# Altium schematic sheet MCU.SchDoc(MCU)
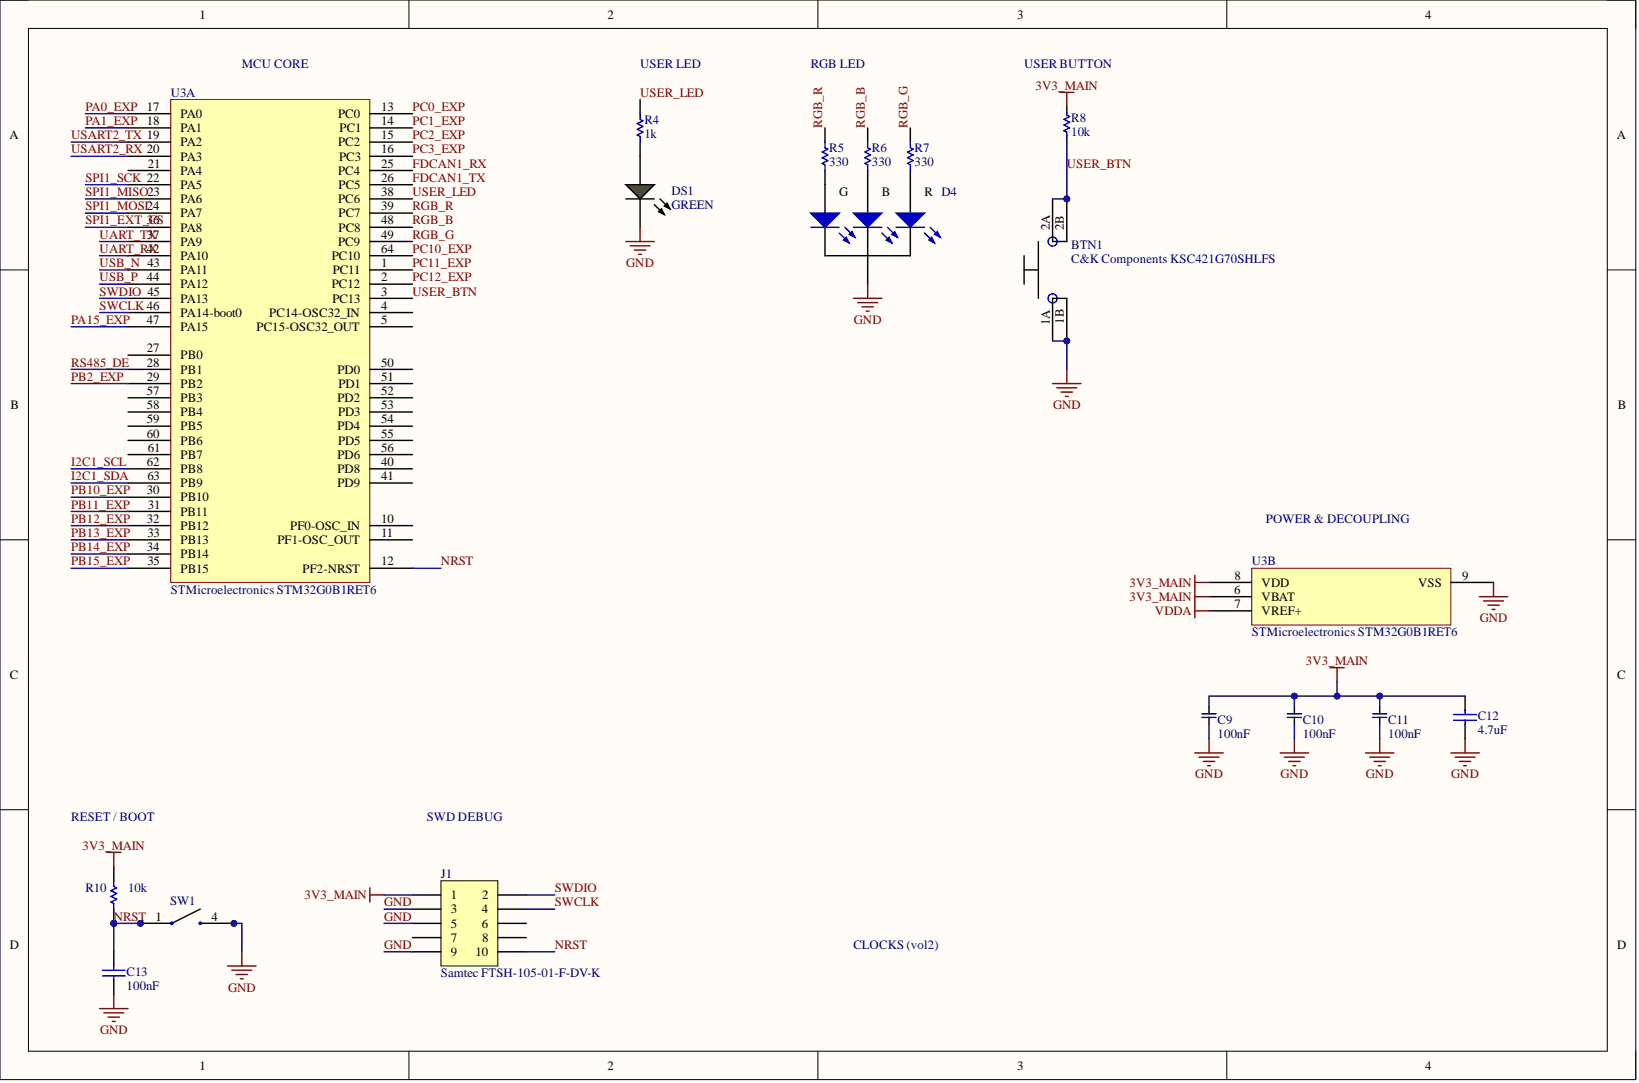
[diagram p0: MCU.SchDoc]

## Parts
BTN1  C&K Components KSC421G70SHLFS  [PCB-uozrol6uzfra7nf3d66d-1]
C9  100nF
C10  100nF
C11  100nF
C12  4.7uF
C13  100nF
D4  Kingbright APFA3010SEKJ3ZGKQBKC  [PCB-xa6wagpttn61v02uvygv-1]  (value not drawn)
DS1  GREEN  [PCB-0runrzwmvqwsv44lu00p-2]
J1  Samtec FTSH-105-01-F-DV-K  Manufacturer Part Number: FTSH-105-01-F-DV-K
R4  1k  [PCB-m2w64qs661eeqm4r43mx-1]
R5  330  [PCB-0002-00066-3]
R6  330  [PCB-0002-00066-3]
R7  330  [PCB-0002-00066-3]
R8  10k
R10  10k
SW1  TE Connectivity FSM2JSMA  [PCB-ceqr8z8zluiv0xnbvd9i-1]  (value not drawn)
U3  STMicroelectronics STM32G0B1RET6  Manufacturer Part Number: STM32G0B1RET6  (parts A,B)

## Nets
3V3_MAIN: C9.2 C10.2 C11.2 C12.2 J1.1 R8.2 R10.2 U3.6(VBAT) U3.8(VDD)
VDDA: U3.7(VREF+)
FDCAN1_RX: U3.25(PC4)
FDCAN1_TX: U3.26(PC5)
GND: BTN1.1A BTN1.1B C9.1 C10.1 C11.1 C12.1 C13.1 D4.2 DS1.2 J1.3 J1.5 J1.9 SW1.4 U3.9(VSS)
I2C1_SCL: U3.62(PB8)
I2C1_SDA: U3.63(PB9)
NRST: C13.2 J1.10 R10.1 SW1.1 U3.12(PF2-NRST)
PA0_EXP: U3.17(PA0)
PA1_EXP: U3.18(PA1)
PA15_EXP: U3.47(PA15)
PB2_EXP: U3.29(PB2)
PB10_EXP: U3.30(PB10)
PB11_EXP: U3.31(PB11)
PB12_EXP: U3.32(PB12)
PB13_EXP: U3.33(PB13)
PB14_EXP: U3.34(PB14)
PB15_EXP: U3.35(PB15)
PC0_EXP: U3.13(PC0)
PC1_EXP: U3.14(PC1)
PC2_EXP: U3.15(PC2)
PC3_EXP: U3.16(PC3)
PC10_EXP: U3.64(PC10)
PC11_EXP: U3.1(PC11)
PC12_EXP: U3.2(PC12)
RGB_B: R6.2 U3.48(PC8)
RGB_G: R7.2 U3.49(PC9)
RGB_R: R5.2 U3.39(PC7)
RS485_DE: U3.28(PB1)
SPI1_EXT_CS: U3.36(PA8)
SPI1_MISO: U3.23(PA6)
SPI1_MOSI: U3.24(PA7)
SPI1_SCK: U3.22(PA5)
SWCLK: J1.4 U3.46(PA14-boot0)
SWDIO: J1.2 U3.45(PA13)
UART_RX: U3.42(PA10)
UART_TX: U3.37(PA9)
USART2_RX: U3.20(PA3)
USART2_TX: U3.19(PA2)
USB_N: U3.43(PA11)
USB_P: U3.44(PA12)
USER_BTN: BTN1.2A BTN1.2B R8.1 U3.3(PC13)
USER_LED: R4.2 U3.38(PC6)
NetD4_1: D4.1 R7.1
NetD4_3: D4.3 R5.1
NetD4_4: D4.4 R6.1
NetDS1_1: DS1.1 R4.1

Not connected: J1 6 7 8; U3 4(PC14-OSC32_IN) 5(PC15-OSC32_OUT) 10(PF0-OSC_IN) 11(PF1-OSC_OUT) 21(PA4) 27(PB0) 40(PD8) 41(PD9) 50(PD0) 51(PD1) 52(PD2) 53(PD3) 54(PD4) 55(PD5) 56(PD6) 57(PB3) 58(PB4) 59(PB5) 60(PB6) 61(PB7)

## Library data of the parts
BTN1 = CMP-7597bcf2a0aaaa50-3: Switch Tactile N.O. SPST Round Button Gull Wing 0.05A 32VDC 1VA 300000Cycle 2.12N SMD T/R; Contact Resistance: 100 mΩ (max); Contacts Type: SPST-NO; Lead-Free Status: Lead Free; Actuator Type: Button; Component Kind: Standard; Contact Material: Silver; Contact Plating: Silver; Library Name: My Personal Space; Manufacturer Part Number: KSC421G70SHLFS; Mounting Style: Surface Mount; Octopart URL: https://octopart.com/ksc421g70shlfs-c%26k+components-7336027; OctopartID: 7597bcf2a0aaaa50; Operating Temperature: 85.0 °C (max); Orientation: Vertical; Packaging: Tape & Reel (TR); REACH SVHC Compliance: No SVHC; RoHS: Compliant; Size-Length: 6.20 mm; Size-Width: 6.20 mm
C9-C11 = CMP-1af8d38ac64bc53f-24: Ceramic capacitor SMD-0603 100nF 50V X7R# K +-10%, Samsung, RoHS; Manufacturer Part Number: CL10B104KB8NNNC; Capacitance Tolerance: ±10%; Dielectric Characteristic: X7R; Lead-Free Status: Lead Free; Voltage Rating (DC): 50.0 V; Capacitance: 100 nF; Case/Package: 0603; Component Kind: Standard; DATE: 2024_09_30; JLCPCB Part #: C1591; Library Name: My Personal Space; Mounting Style: Surface Mount; Octopart URL: https://octopart.com/part/samsung-electro-mechanics/CL10B104KB8NNNC; OctopartID: 1af8d38ac64bc53f; PN Digikey: 1276-1000-1-ND; PN FAB: CL10B104KB8NNNC; PN JLCPCB: C1591; Price DK: 0.1; Price JLCPCB: 0.0023; RoHS: Compliant
C12 = CMP-8267229-2: Multilayer Ceramic Capacitors MLCC - SMD/SMT 0805 4.7uF 35volts X5R 20%; Manufacturer Part Number: GRM219R6YA475MA73D; Capacitance: 4.7e-06 F; Case/Package (SI): 2012; Voltage Rating (DC): 35.0 V; Capacitance Tolerance: ±20%; Case/Package: 0805; Component Kind: Standard; Dielectric Characteristic: X5R; Library Name: My Personal Space; Lifecycle Status: Active; Octopart Url: https://octopart.com/grm219r6ya475ma73d-murata-27475762; OctopartID: 05c0cd691f94da07; Packaging: Cut Tape (CT); REACH SVHC Compliance: No SVHC; RoHS: Compliant; Size-Length: 0.002 m; Size-Thickness: 0.00085 m; Size-Width: 0.00125 m
C13 = CMP-e7d62c864ecd3811-3: Capacitor; ECWF, Met Polypropylene Film Cap, Radial, 50V, 10uF, 5%, Blk ST; Manufacturer Part Number: ECW-F4474JL; Capacitance: 470 nF; Lead Length: 25.0 mm; Lead-Free Status: Lead Free; Capacitance Tolerance: ±5%; Component Kind: Standard; Dielectric Material: Polypropylene; Library Name: My Personal Space; Lifecycle Status: Not Listed by Manufacturer; Mounting Style: Through Hole; Octopart Url: https://octopart.com/ecw-f4474jl-panasonic-39488637; OctopartID: e7d62c864ecd3811; Operating Temperature: 105 °C (max); Packaging: Bulk; RoHS: Compliant; Size-Height: 5mm; Size-Length: 11mm; Voltage Rating (DC): 50v
D4 = CMP-91425c46545c4e85-2: 3.0MMX1.0MM Right Angle SMD Chip Led Lamp; Detailed Description: Red, Green, Blue (RGB) 625nm Red, 525nm Green, 465nm Blue LED Indication - Discrete 2.2V Red, 3.3V Green, 3.3V Blue 4-SMD, No Lead; Component Kind: Standard; Digikey Part Number: 754-2148-1-ND; Library Name: My Personal Space; Manufacturer: Kingbright; Manufacturer Part Number: APFA3010SEKJ3ZGKQBKC; Octopart URL: https://octopart.com/apfa3010sekj3zgkqbkc-kingbright-68083832; OctopartID: 91425c46545c4e85; RoHS: Compliant
DS1 = CMP-e1642866a00b3ea3-15: 2.4 V Green LED 1608 (0603) SMD, Lite-On LTST-C190KGKT; Case/Package (SI): 1608; Forward Voltage: 2.00 V; Lead-Free Status: Lead Free; Lens Type: Non-Diffused; Luminous Intensity: 35.0 mcd; Viewing Angle: 130 °; Wavelength: 574 nm; Case/Package: 0603; Color: Green; Component Kind: Standard; Library Name: My Personal Space; Manufacturer Part Number: LTST-C190KGKT; Mounting Style: Surface Mount, Vertical; Octopart URL: https://octopart.com/ltst-c190kgkt-lite-on-549897; OctopartID: e1642866a00b3ea3; Operating Temperature: 85.0 °C (max); Packaging: Cut Tape (CT), Tape & Reel (TR); RoHS: Compliant; Size-Height: 800 µm; Size-Length: 1.60 mm; Size-Width: 800 µm
J1 = CMP-51de9854a3aa8e19-9: Conn Unshrouded Header HDR 10 POS 1.27mm Solder ST SMD Automotive Tube; Manufacturer: Samtec Inc.; Component Kind: Standard; Library Name: My Personal Space
R4 = CMP-1853278-34: YAGEO (PHYCOMP)   RC0603FR-071KL   RESISTOR, THICK FILM, 1K, 0.1W, 1%; Lead-Free Status: Lead Free; Resistance: 1000.0 Ω; Voltage Rating (DC): 50.0 V; Case/Package: 0603; Component Kind: Standard; Library Name: My Personal Space; Manufacturer Part Number: RC0603FR-071KL; Mounting Style: Surface Mount; Number of Pins: 2; Octopart Url: https://octopart.com/rc0603fr-071kl-yageo-55402882; OctopartID: 36a1fce67245e966; Power Rating: 0.1 W; REACH SVHC Compliance: No SVHC; Resistance Tolerance: ±1%; RoHS: Compliant; Size-Height: 0.00045 m; Size-Length: 0.0016 m; Size-Width: 0.0008 m
R5-R7 = CMP-1853593-9: Res Thick Film 0603 330 Ohm 1% 0.1W(1/10W) ±100ppm/C Pad SMD T/R; Manufacturer Part Number: RC0603FR-07330RL; Case/Package (SI): 1608; Lead-Free Status: Lead Free; Power Rating: 100 mW; Resistance: 330 Ω; Temperature Coefficient: -100 ppm/°C to 100 ppm/°C; Voltage Rating (DC): 75.0 V, 50.0 V; Case/Package: 0603; Component Kind: Standard; Library Name: My Personal Space; Mounting Style: Surface Mount; Number of Pins: 2; Octopart URL: https://octopart.com/rc0603fr-07330rl-yageo-40301083; OctopartID: ba1971756d6465de; Operating Temperature: 155 °C (max); Packaging: Tape & Reel; REACH SVHC Compliance: No SVHC; Resistance Tolerance: ±1%; RoHS: Compliant; Size-Height: 450 µm; Size-Length: 1.60 mm; Size-Width: 800 µm
R8 = CMP-c4650f0d5cc11ba2-40: RES 10K OHM 1% 1/10W 0603; Manufacturer: Yageo; Manufacturer Part Number: RC0603FR-0710KL; Vendor Part Number: 311-10.0KHRCT-ND; Power: 0.1W; Resistance: 10 kΩ; Temperature Coefficient: ±100ppm/°C; Vendor: Digikey; Vendor Cost: 0.10; Component Kind: Standard; Composition: Thick film; Features: Moisture Resistant; Height - Seated (Max): 0.022" (0.55mm); HelpURL: https://www.yageo.com/upload/media/product/productsearch/datasheet/rchip/PYu-RC_Group_51_RoHS_L_12.pdf; Library Name: My Personal Space; Octopart URL: https://octopart.com/rc0603fr-0710kl-yageo-40025538; OctopartID: c4650f0d5cc11ba2; Operating Temperature: -55°C ~ 155°C; Package / Case: 0603; Size / Dimension: 0.063" L x 0.031" W (1.60mm x 0.80mm); Supplier Device Package: 0603; Tolerance: ±1%
R10 = CMP-3651607-3: Thick Film Resistors - SMD 0402 47ohms 5% Tol; Manufacturer Part Number: ERJ-2GEJ470X; Lead-Free Status: Lead Free; Power Rating: 0.1 W; Resistance: 47.0 Ω; Voltage Rating (DC): 50.0 V; Case/Package: 0402; Component Kind: Standard; Library Name: My Personal Space; Lifecycle Status: Not Listed by Manufacturer; Mounting Style: Surface Mount; Octopart Url: https://octopart.com/erj-2gej470x-panasonic-55420769; OctopartID: 7e7eb439fc79a1b9; Packaging: Cut Tape (CT); REACH SVHC Compliance: No SVHC; Resistance Tolerance: ±5%; RoHS: Compliant; Size-Height: 0.00035 m; Size-Length: 0.001 m; Size-Width: 0.0005 m
SW1 = CMP-5bcd9002db93840a-9: Switch Tactile OFF (ON) SPST Round Button Gull Wing 0.05A 24VDC 1.57N SMD Loose; Actuator Type: Standard; Contact Current Rating: 50.0 mA; Contact Resistance: 100 mΩ (max); ELV Compliant: Compliant; Insulation Resistance: 100 MΩ; Lead-Free Status: Lead Free; Color: Black; Component Kind: Standard; Contact Material: Copper Alloy; Contact Plating: Silver; Contacts Type: SPST; Library Name: My Personal Space; Lifecycle Status: Active; Manufacturer Part Number: FSM2JSMA; Mounting Style: Surface Mount; Octopart URL: https://octopart.com/fsm2jsma-te+connectivity-55488003; OctopartID: 4ab380f7c1d69574; Operating Temperature: 70.0 °C (max); Orientation: Vertical; Packaging: Bulk; REACH SVHC Compliance: No SVHC; RoHS: Compliant
U3 = CMP-0c25c3952bc2d3e2-3: MCU 32-bit ARM Cortex M0+ RISC 512KB Flash 1.8V/2.5V/3.3V 64-Pin LQFP Tray; Component Kind: Standard; Library Name: My Personal Space; Lifecycle Status: Not Listed by Manufacturer; Octopart Url: https://octopart.com/stm32g0b1ret6-stmicroelectronics-112853633; OctopartID: 0c25c3952bc2d3e2
